# Altium schematic sheet ICWSHLP2_Head_2x2.SchDoc(ICWSHLP2_Head_2x2)
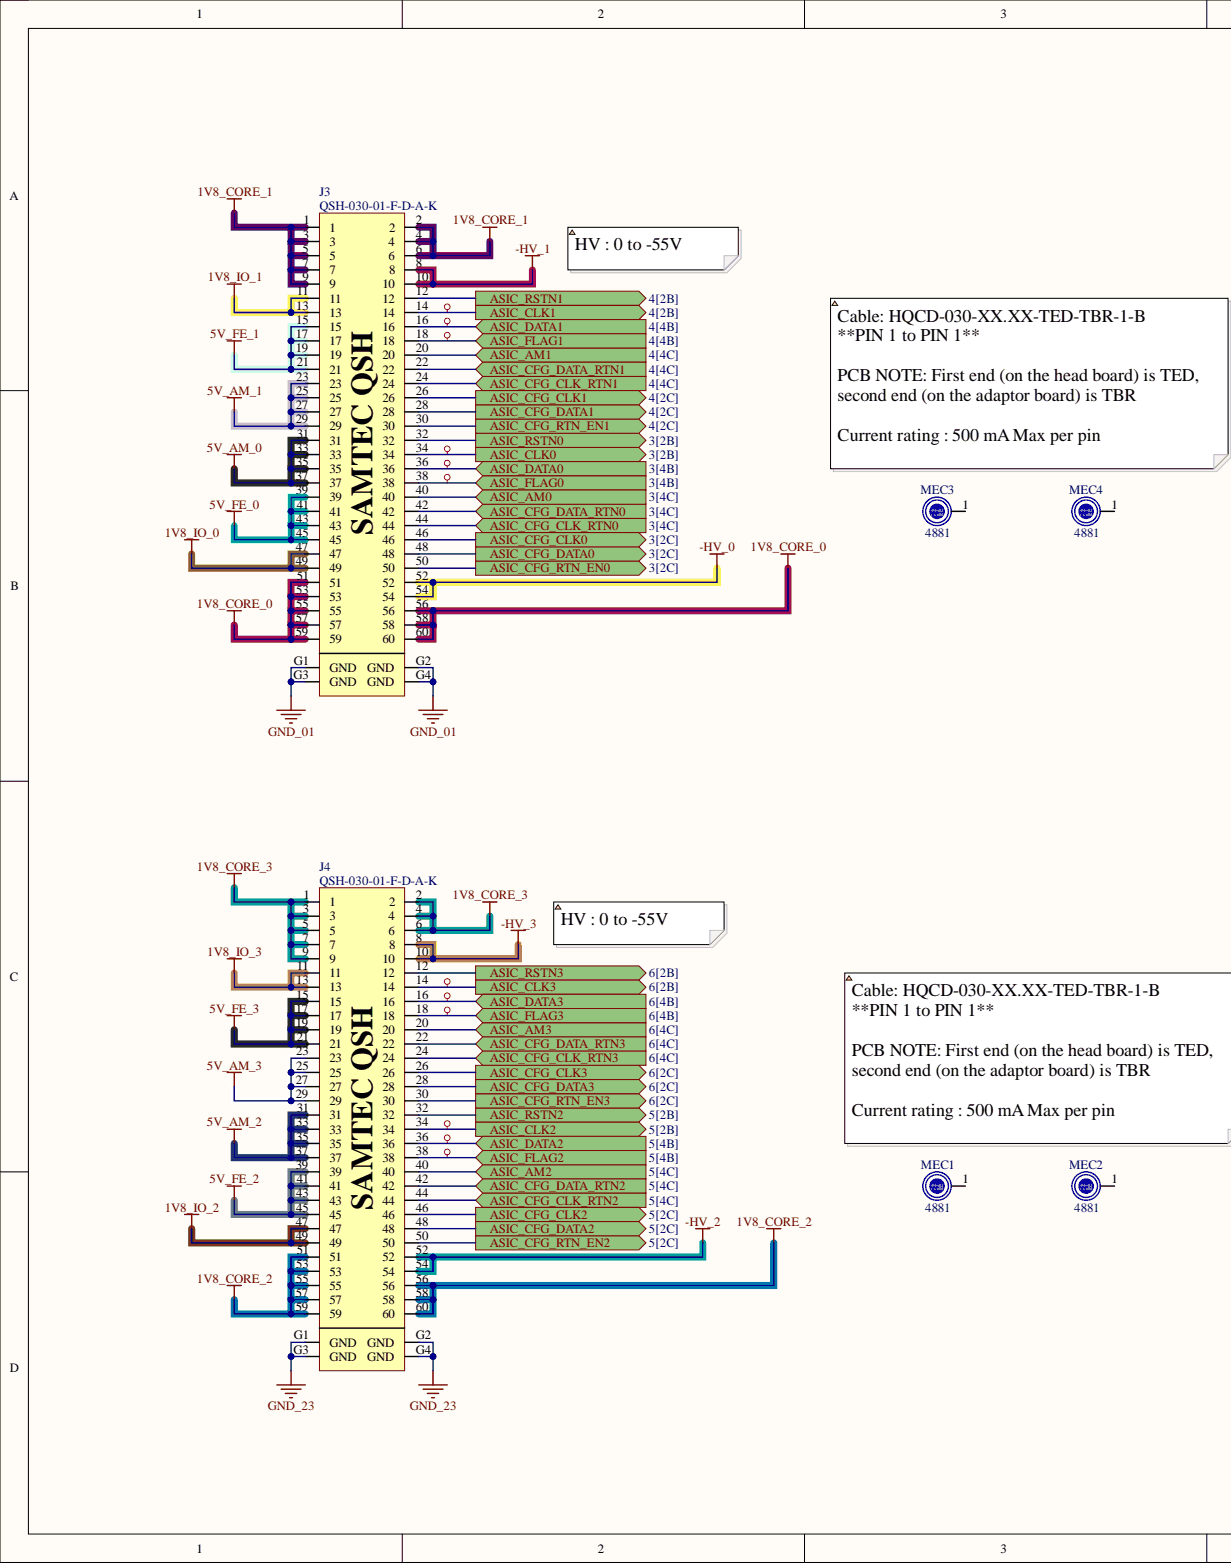
[diagram p0: ICWSHLP2_Head_2x2.SchDoc, left]
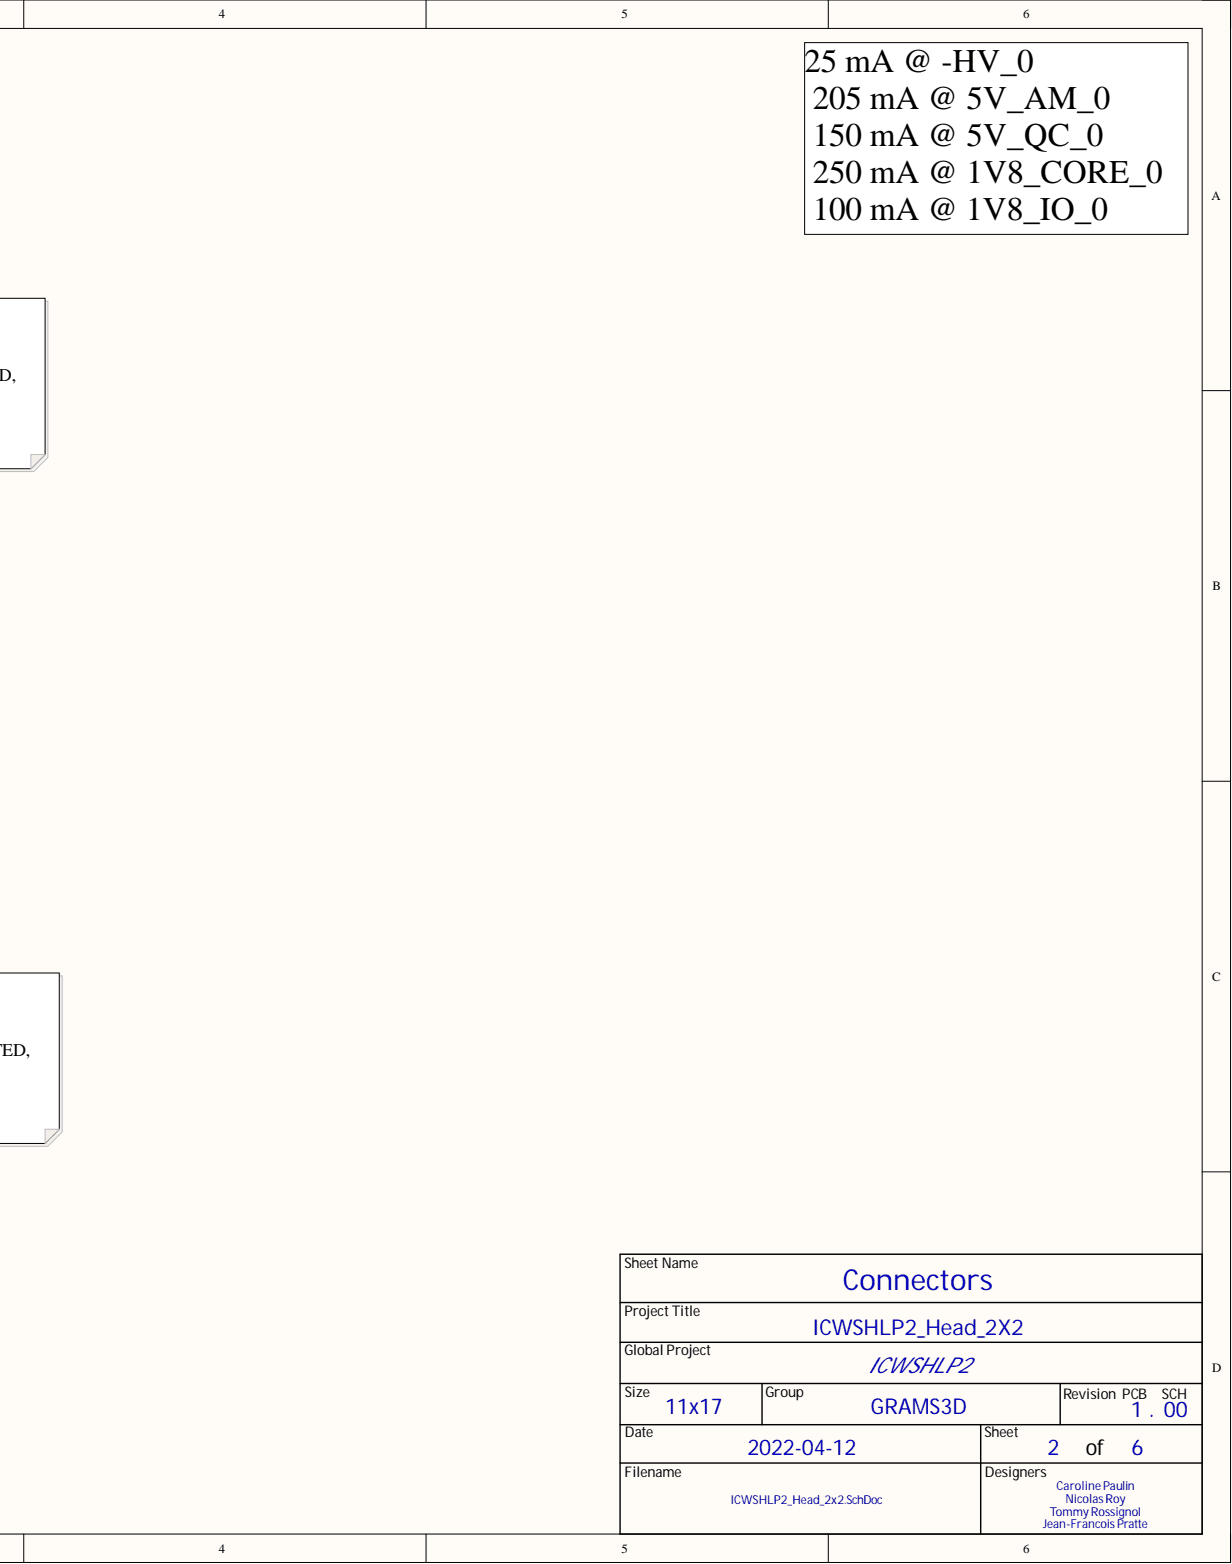
[diagram p1: ICWSHLP2_Head_2x2.SchDoc, right]

## Parts
J3  QSH-030-01-F-D-A-K  [CON_QSH-030-01-F-D-A-K]
J4  QSH-030-01-F-D-A-K  [CON_QSH-030-01-F-D-A-K]
MEC1  4881  value #4-40  [MEC_PEM_4881]
MEC2  4881  value #4-40  [MEC_PEM_4881]
MEC3  4881  value #4-40  [MEC_PEM_4881]
MEC4  4881  value #4-40  [MEC_PEM_4881]

## Ports
ASIC_AM0, ASIC_AM1, ASIC_AM2, ASIC_AM3, ASIC_CFG_CLK0, ASIC_CFG_CLK1, ASIC_CFG_CLK2, ASIC_CFG_CLK3, ASIC_CFG_CLK_RTN0, ASIC_CFG_CLK_RTN1, ASIC_CFG_CLK_RTN2, ASIC_CFG_CLK_RTN3, ASIC_CFG_DATA0, ASIC_CFG_DATA1, ASIC_CFG_DATA2, ASIC_CFG_DATA3, ASIC_CFG_DATA_RTN0, ASIC_CFG_DATA_RTN1, ASIC_CFG_DATA_RTN2, ASIC_CFG_DATA_RTN3, ASIC_CFG_RTN_EN0, ASIC_CFG_RTN_EN1, ASIC_CFG_RTN_EN2, ASIC_CFG_RTN_EN3, ASIC_CLK0, ASIC_CLK1, ASIC_CLK2, ASIC_CLK3, ASIC_DATA0, ASIC_DATA1, ASIC_DATA2, ASIC_DATA3, ASIC_FLAG0, ASIC_FLAG1, ASIC_FLAG2, ASIC_FLAG3, ASIC_RSTN0, ASIC_RSTN1, ASIC_RSTN2, ASIC_RSTN3

## Nets
1V8_CORE_0: J3.51 J3.53 J3.55 J3.56 J3.57 J3.58 J3.59 J3.60
1V8_CORE_1: J3.1 J3.2 J3.3 J3.4 J3.5 J3.6 J3.7 J3.9
1V8_CORE_2: J4.51 J4.53 J4.55 J4.56 J4.57 J4.58 J4.59 J4.60
1V8_CORE_3: J4.1 J4.2 J4.3 J4.4 J4.5 J4.6 J4.7 J4.9
1V8_IO_0: J3.47 J3.49
1V8_IO_1: J3.11 J3.13
1V8_IO_2: J4.47 J4.49
1V8_IO_3: J4.11 J4.13
5V_AM_0: J3.31 J3.33 J3.35 J3.37
5V_AM_1: J3.23 J3.25 J3.27 J3.29
5V_AM_2: J4.31 J4.33 J4.35 J4.37
5V_AM_3: J4.23 J4.25 J4.27 J4.29
5V_FE_0: J3.39 J3.41 J3.43 J3.45
5V_FE_1: J3.15 J3.17 J3.19 J3.21
5V_FE_2: J4.39 J4.41 J4.43 J4.45
5V_FE_3: J4.15 J4.17 J4.19 J4.21
-HV_0: J3.52 J3.54
-HV_1: J3.8 J3.10
-HV_2: J4.52 J4.54
-HV_3: J4.8 J4.10
GND_01: J3.G1(GND) J3.G2(GND) J3.G3(GND) J3.G4(GND)
GND_23: J4.G1(GND) J4.G2(GND) J4.G3(GND) J4.G4(GND)
ASIC_AM0: J3.40 ; port ASIC_AM0
ASIC_AM1: J3.20 ; port ASIC_AM1
ASIC_AM2: J4.40 ; port ASIC_AM2
ASIC_AM3: J4.20 ; port ASIC_AM3
ASIC_CFG_CLK0: J3.46 ; port ASIC_CFG_CLK0
ASIC_CFG_CLK1: J3.26 ; port ASIC_CFG_CLK1
ASIC_CFG_CLK2: J4.46 ; port ASIC_CFG_CLK2
ASIC_CFG_CLK3: J4.26 ; port ASIC_CFG_CLK3
ASIC_CFG_CLK_RTN0: J3.44 ; port ASIC_CFG_CLK_RTN0
ASIC_CFG_CLK_RTN1: J3.24 ; port ASIC_CFG_CLK_RTN1
ASIC_CFG_CLK_RTN2: J4.44 ; port ASIC_CFG_CLK_RTN2
ASIC_CFG_CLK_RTN3: J4.24 ; port ASIC_CFG_CLK_RTN3
ASIC_CFG_DATA0: J3.48 ; port ASIC_CFG_DATA0
ASIC_CFG_DATA1: J3.28 ; port ASIC_CFG_DATA1
ASIC_CFG_DATA2: J4.48 ; port ASIC_CFG_DATA2
ASIC_CFG_DATA3: J4.28 ; port ASIC_CFG_DATA3
ASIC_CFG_DATA_RTN0: J3.42 ; port ASIC_CFG_DATA_RTN0
ASIC_CFG_DATA_RTN1: J3.22 ; port ASIC_CFG_DATA_RTN1
ASIC_CFG_DATA_RTN2: J4.42 ; port ASIC_CFG_DATA_RTN2
ASIC_CFG_DATA_RTN3: J4.22 ; port ASIC_CFG_DATA_RTN3
ASIC_CFG_RTN_EN0: J3.50 ; port ASIC_CFG_RTN_EN0
ASIC_CFG_RTN_EN1: J3.30 ; port ASIC_CFG_RTN_EN1
ASIC_CFG_RTN_EN2: J4.50 ; port ASIC_CFG_RTN_EN2
ASIC_CFG_RTN_EN3: J4.30 ; port ASIC_CFG_RTN_EN3
ASIC_CLK0: J3.34 ; port ASIC_CLK0
ASIC_CLK1: J3.14 ; port ASIC_CLK1
ASIC_CLK2: J4.34 ; port ASIC_CLK2
ASIC_CLK3: J4.14 ; port ASIC_CLK3
ASIC_DATA0: J3.36 ; port ASIC_DATA0
ASIC_DATA1: J3.16 ; port ASIC_DATA1
ASIC_DATA2: J4.36 ; port ASIC_DATA2
ASIC_DATA3: J4.16 ; port ASIC_DATA3
ASIC_FLAG0: J3.38 ; port ASIC_FLAG0
ASIC_FLAG1: J3.18 ; port ASIC_FLAG1
ASIC_FLAG2: J4.38 ; port ASIC_FLAG2
ASIC_FLAG3: J4.18 ; port ASIC_FLAG3
ASIC_RSTN0: J3.32 ; port ASIC_RSTN0
ASIC_RSTN1: J3.12 ; port ASIC_RSTN1
ASIC_RSTN2: J4.32 ; port ASIC_RSTN2
ASIC_RSTN3: J4.12 ; port ASIC_RSTN3

Not connected: MEC1 1; MEC2 1; MEC3 1; MEC4 1

## Library data of the parts
J3 J4 = CON_QSH-030-01-F-D-A-K: CON - RCPT 0.5mm Q STRIP 30 POS SMD GOLD - 60 -SMD; Manufacturer #1: Samtec inc; Cryo: UNKNOWN; GRAMS Lib Spec: 3.2; Polarized: Yes; Solder Paste Type: TYPE 3; Stencil Thickness (Recommended): .152 mm; Value: 60-POS; Voltage Rating: 175VAC; Assembly Type: SMD; Component Kind: Standard; HelpURL: https://www.samtec.com/products/qsh; Library Name: GRAMS_MASTER_LIB.IntLib; Magnetic Material: UNKNOWN; Package: 60-SMD; Part Number #1: QSH-030-01-F-D-A-K; Part Number #2: -; Supplier 1: Samtec inc; Supplier Part Number 1: QSH-030-01-F-D-A-K; Temperature: -55 °C to +125 °C; CreatedBy/Date: Caroline Paulin - 01/11/2021; VerifiedBy: Phil M. 12/01/2022
MEC1-MEC4 = MEC_PEM_4881: MEC - ROUND STANDOFF #4-40 STEEL 1.6mm - SMD; Manufacturer #1: Keystone Electronics corp; Cryo: UNKNOWN; GRAMS Lib Spec: 3.2; Polarized: NO; Solder Paste Type: TYPE 3; Assembly Type: SMD; Component Kind: Standard; HelpURL: https://www.keyelco.com/product.cfm/product_id/14249; Library Name: GRAMS_MASTER_LIB_SCH.SchLib; Magnetic Material: UNKNOWN; Package: SMD; Part Number #1: 4881; Stencil Thickness (Recommended): UNKNOWN; Supplier 1: Digi-key; Supplier Part Number 1: 36-4881CT-ND; CreatedBy/Date: C.Paulin - 2021/12/02; VerifiedBy: Phil M. - 12/01/2022
